# Altium schematic sheet ALTERA_MAX10_ARDUINO_BOARD_ARDUINO_CONNECTOR.SchDoc(ALTERA_MAX10_ARDUINO_BOARD_ARDUINO_CONNECTOR)
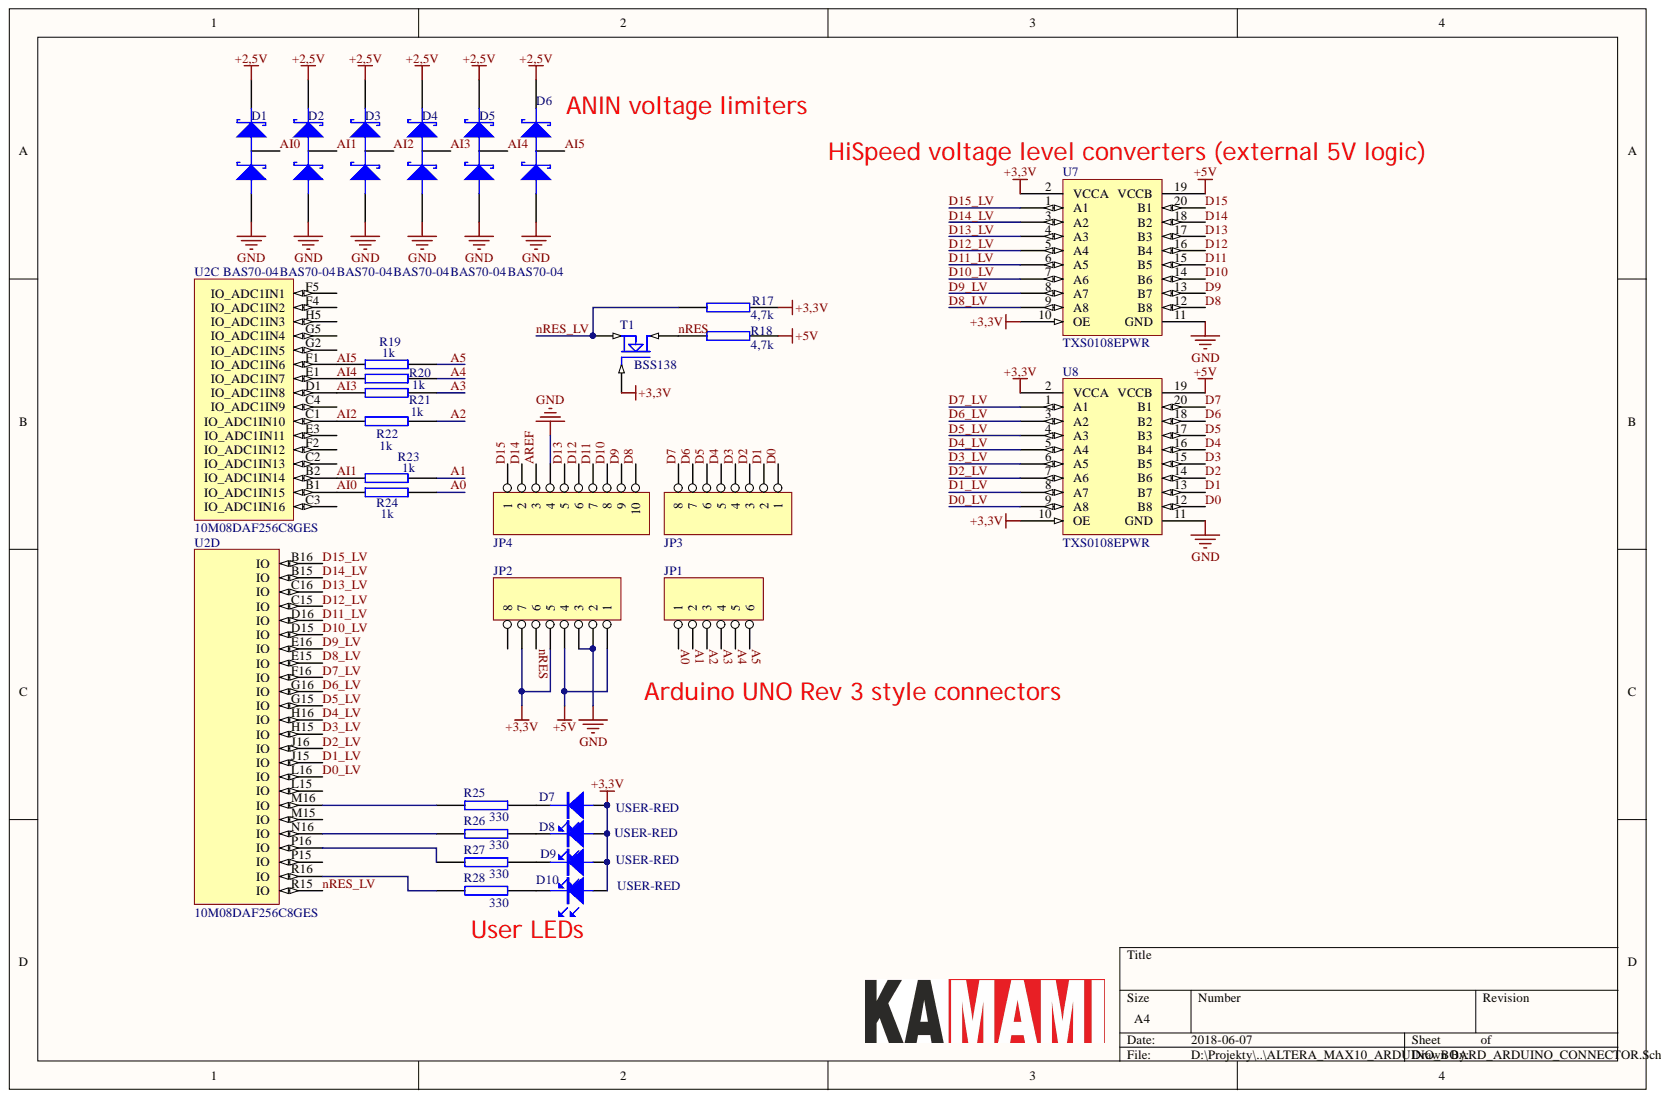
[diagram p0: ALTERA_MAX10_ARDUINO_BOARD_ARDUINO_CONNECTOR.SchDoc]

## Parts
D1  BAS70-04  [SOT-23]
D2  BAS70-04  [SOT-23]
D3  BAS70-04  [SOT-23]
D4  BAS70-04  [SOT-23]
D5  BAS70-04  [SOT-23]
D6  BAS70-04  [SOT-23]
D7  USER-RED  [0805_LED]
D8  USER-RED  [0805_LED]
D9  USER-RED  [0805_LED]
D10  USER-RED  [0805_LED]
JP1  HEADER 6  [SIP-6]  (value not drawn)
JP2  HEADER 8  [sip-8]  (value not drawn)
JP3  HEADER 8  [sip-8]  (value not drawn)
JP4  HEADER 10  [sip-10]  (value not drawn)
R17  4,7k  [0603R]
R18  4,7k  [0603R]
R19  1k  [0603R]
R20  1k  [0603R]
R21  1k  [0603R]
R22  1k  [0603R]
R23  1k  [0603R]
R24  1k  [0603R]
R25  330  [0603R]
R26  330  [0603R]
R27  330  [0603R]
R28  330  [0603R]
T1  BSS138  [SOT-23]
U2  10M08DAF256C8GES  [BGA256C100P16X16_1700X1700X145]  (parts C,D)
U7  TXS0108EPWR  [TSSOP20]
U8  TXS0108EPWR  [TSSOP20]

## Nets
+2,5V: D1.2 D2.2 D3.2 D4.2 D5.2 D6.2
+3,3V: D7.1 D8.1 D9.1 D10.1 JP2.5 JP2.7 R17.2 T1.1 U7.2(VCCA) U7.10(OE) U8.2(VCCA) U8.10(OE)
+5V: JP2.1 JP2.4 R18.2 U7.19(VCCB) U8.19(VCCB)
GND: D1.1 D2.1 D3.1 D4.1 D5.1 D6.1 JP2.2 JP2.3 JP4.4 U7.11(GND) U8.11(GND)
A0: JP1.1 R24.2
A1: JP1.2 R23.2
A2: JP1.3 R22.2
A3: JP1.4 R21.2
A4: JP1.5 R20.2
A5: JP1.6 R19.2
AI0: D1.3 R24.1 U2.B1(IO_ADC1IN15)
AI1: D2.3 R23.1 U2.B2(IO_ADC1IN14)
AI2: D3.3 R22.1 U2.C1(IO_ADC1IN10)
AI3: D4.3 R21.1 U2.D1(IO_ADC1IN8)
AI4: D5.3 R20.1 U2.E1(IO_ADC1IN7)
AI5: D6.3 R19.1 U2.F1(IO_ADC1IN6)
AREF: JP4.3
D0: JP3.1 U8.12(B8)
D0_LV: U2.L16(IO) U8.9(A8)
D1: JP3.2 U8.13(B7)
D1_LV: U2.J15(IO) U8.8(A7)
D2: JP3.3 U8.14(B6)
D2_LV: U2.J16(IO) U8.7(A6)
D3: JP3.4 U8.15(B5)
D3_LV: U2.H15(IO) U8.6(A5)
D4: JP3.5 U8.16(B4)
D4_LV: U2.H16(IO) U8.5(A4)
D5: JP3.6 U8.17(B3)
D5_LV: U2.G15(IO) U8.4(A3)
D6: JP3.7 U8.18(B2)
D6_LV: U2.G16(IO) U8.3(A2)
D7: JP3.8 U8.20(B1)
D7_LV: U2.F16(IO) U8.1(A1)
D8: JP4.10 U7.12(B8)
D8_LV: U2.E15(IO) U7.9(A8)
D9: JP4.9 U7.13(B7)
D9_LV: U2.E16(IO) U7.8(A7)
D10: JP4.8 U7.14(B6)
D10_LV: U2.D15(IO) U7.7(A6)
D11: JP4.7 U7.15(B5)
D11_LV: U2.D16(IO) U7.6(A5)
D12: JP4.6 U7.16(B4)
D12_LV: U2.C15(IO) U7.5(A4)
D13: JP4.5 U7.17(B3)
D13_LV: U2.C16(IO) U7.4(A3)
D14: JP4.2 U7.18(B2)
D14_LV: U2.B15(IO) U7.3(A2)
D15: JP4.1 U7.20(B1)
D15_LV: U2.B16(IO) U7.1(A1)
nRES: JP2.6 R18.1 T1.3
nRES_LV: R17.1 T1.2 U2.R15(IO)
NetD7_2: D7.2 R25.2
NetD8_2: D8.2 R26.2
NetD9_2: D9.2 R27.2
NetD10_2: D10.2 R28.2
NetR25_1: R25.1 U2.M16(IO)
NetR26_1: R26.1 U2.N16(IO)
NetR27_1: R27.1 U2.P16(IO)
NetR28_1: R28.1 U2.R16(IO)

Not connected: JP2 8; U2 C2(IO_ADC1IN13) C3(IO_ADC1IN16) C4(IO_ADC1IN9) E3(IO_ADC1IN11) F2(IO_ADC1IN12) F4(IO_ADC1IN2) F5(IO_ADC1IN1) G2(IO_ADC1IN5) G5(IO_ADC1IN4) H5(IO_ADC1IN3) L15(IO) M15(IO) P15(IO)

## Library data of the parts
D1-D6 = D_DIODE_SCHOTTKY_SERIAL: Library Name: Miscellaneous Devices.SchLib; Component Kind: Standard
D7-D10 = LED: Library Name: Miscellaneous Devices.SchLib; Component Kind: Standard
JP2 JP3 = HEADER 8: 8 Pin Header; Library Name: Miscellaneous Devices.SchLib; Component Kind: Standard
R17-R28 = res2: Library Name: Miscellaneous Devices.SchLib; Component Kind: Standard
T1 = MOSFET_N_SOT23: Library Name: Miscellaneous Devices.SchLib; Component Kind: Standard
JP1 = HEADER 6: Library Name: Miscellaneous Devices.SchLib; Component Kind: Standard
JP4 = HEADER 10: Library Name: Miscellaneous Devices.SchLib; Component Kind: Standard
U2 = 10M08DAF256C8GES: Library Name: Altera_MAX10.SchLib; Component Kind: Standard
U7 U8 = TXS0108EPWR: Library Name: TI_Logic.SchLib; Component Kind: Standard
